# Altium schematic sheet TE0720_JB1.SchDoc(TE0720_JB1)
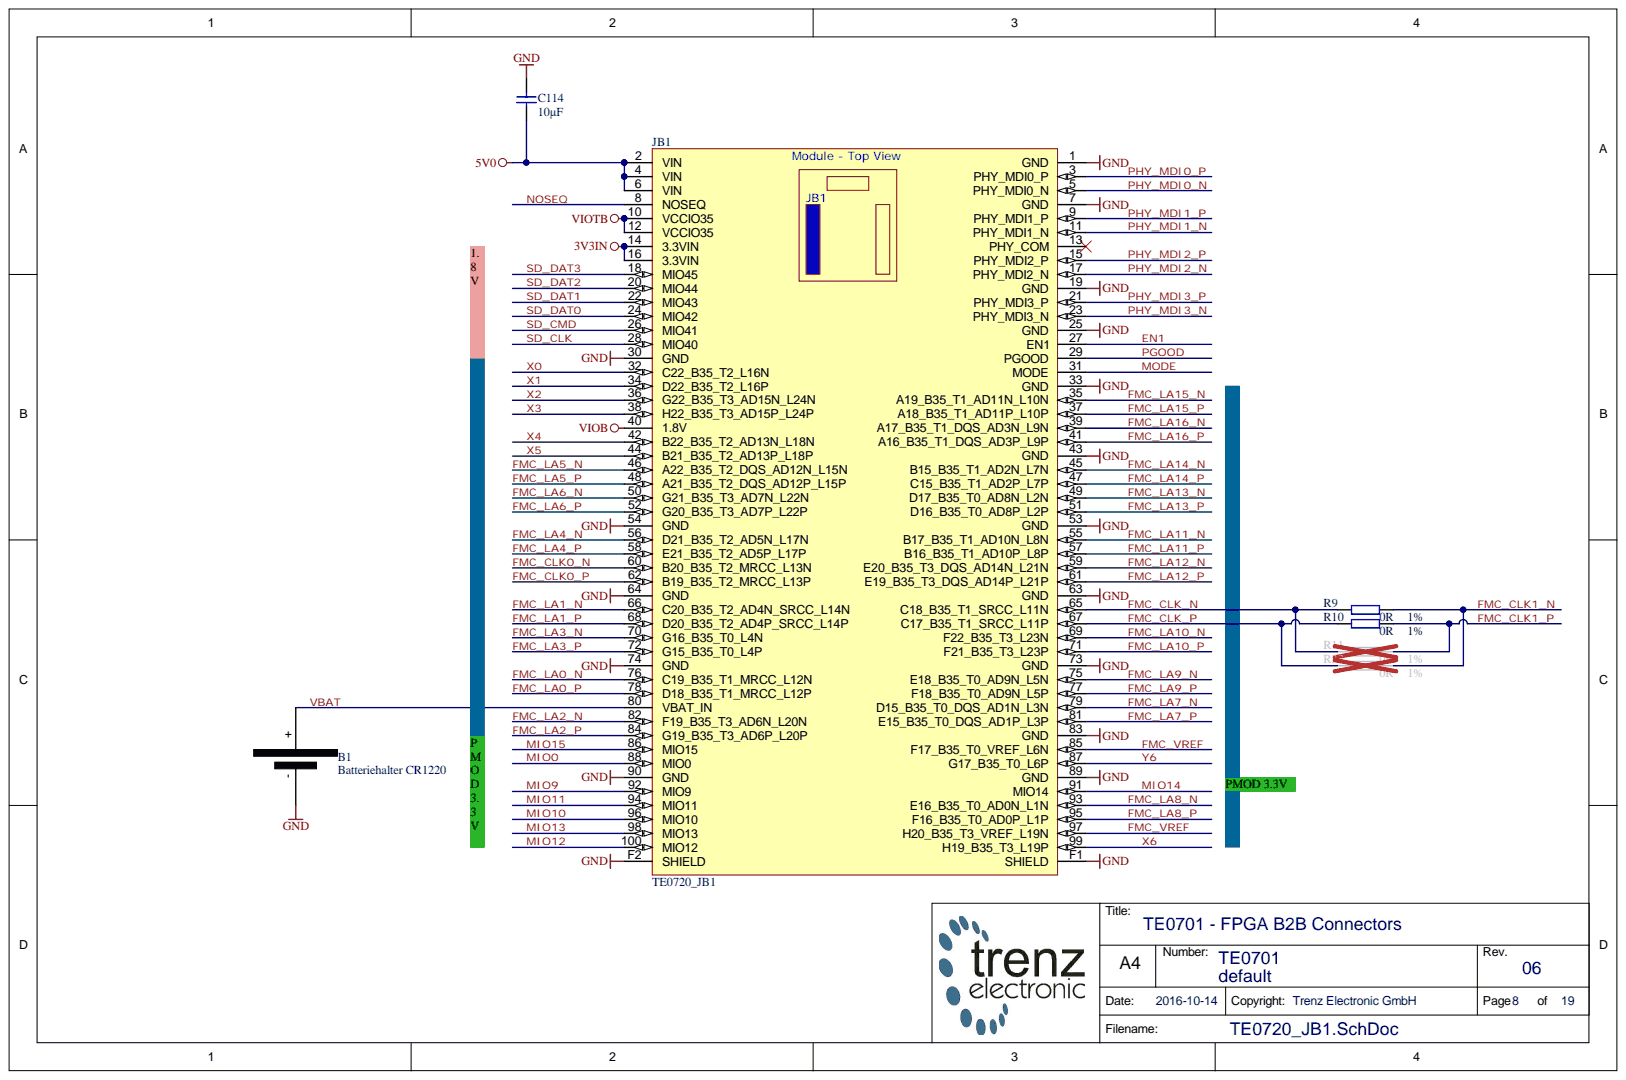
[diagram p0: TE0720_JB1.SchDoc]

## Parts
B1  Batteriehalter CR1220  [CR1220]
C114  10µF  [CAPC2012X135N]
JB1  TE0720_JB1  [LSHM-150-XXX-X-DV-A-X]
R9  0R  Tolerance: 1%  [RESC1005X40N]
R10  0R  Tolerance: 1%  [RESC1005X40N]
R11  0R  Tolerance: 1%  [RESC1005X40N]
R33  0R  Tolerance: 1%  [RESC1005X40N]

## Nets
3V3IN: JB1.14(3.3VIN) JB1.16(3.3VIN)
5V0: C114.2 JB1.2(VIN) JB1.4(VIN) JB1.6(VIN)
GND: B1.- C114.1 JB1.1(GND) JB1.7(GND) JB1.19(GND) JB1.25(GND) JB1.30(GND) JB1.33(GND) JB1.43(GND) JB1.53(GND) JB1.54(GND) JB1.63(GND) JB1.64(GND) JB1.73(GND) JB1.74(GND) JB1.83(GND) JB1.89(GND) JB1.90(GND) JB1.F1(SHIELD) JB1.F2(SHIELD)
VIOB: JB1.40(1.8V)
VIOTB: JB1.10(VCCIO35) JB1.12(VCCIO35)
EN1: JB1.27(EN1)
FMC_CLK0_N: JB1.60(B20_B35_T2_MRCC_L13N)
FMC_CLK0_P: JB1.62(B19_B35_T2_MRCC_L13P)
FMC_CLK1_N: R9.2 R33.2
FMC_CLK1_P: R10.2 R11.2
FMC_CLK_N: JB1.65(C18_B35_T1_SRCC_L11N) R9.1 R11.1
FMC_CLK_P: JB1.67(C17_B35_T1_SRCC_L11P) R10.1 R33.1
FMC_LA0_N: JB1.76(C19_B35_T1_MRCC_L12N)
FMC_LA0_P: JB1.78(D18_B35_T1_MRCC_L12P)
FMC_LA1_N: JB1.66(C20_B35_T2_AD4N_SRCC_L14N)
FMC_LA1_P: JB1.68(D20_B35_T2_AD4P_SRCC_L14P)
FMC_LA2_N: JB1.82(F19_B35_T3_AD6N_L20N)
FMC_LA2_P: JB1.84(G19_B35_T3_AD6P_L20P)
FMC_LA3_N: JB1.70(G16_B35_T0_L4N)
FMC_LA3_P: JB1.72(G15_B35_T0_L4P)
FMC_LA4_N: JB1.56(D21_B35_T2_AD5N_L17N)
FMC_LA4_P: JB1.58(E21_B35_T2_AD5P_L17P)
FMC_LA5_N: JB1.46(A22_B35_T2_DQS_AD12N_L15N)
FMC_LA5_P: JB1.48(A21_B35_T2_DQS_AD12P_L15P)
FMC_LA6_N: JB1.50(G21_B35_T3_AD7N_L22N)
FMC_LA6_P: JB1.52(G20_B35_T3_AD7P_L22P)
FMC_LA7_N: JB1.79(D15_B35_T0_DQS_AD1N_L3N)
FMC_LA7_P: JB1.81(E15_B35_T0_DQS_AD1P_L3P)
FMC_LA8_N: JB1.93(E16_B35_T0_AD0N_L1N)
FMC_LA8_P: JB1.95(F16_B35_T0_AD0P_L1P)
FMC_LA9_N: JB1.75(E18_B35_T0_AD9N_L5N)
FMC_LA9_P: JB1.77(F18_B35_T0_AD9N_L5P)
FMC_LA10_N: JB1.69(F22_B35_T3_L23N)
FMC_LA10_P: JB1.71(F21_B35_T3_L23P)
FMC_LA11_N: JB1.55(B17_B35_T1_AD10N_L8N)
FMC_LA11_P: JB1.57(B16_B35_T1_AD10P_L8P)
FMC_LA12_N: JB1.59(E20_B35_T3_DQS_AD14N_L21N)
FMC_LA12_P: JB1.61(E19_B35_T3_DQS_AD14P_L21P)
FMC_LA13_N: JB1.49(D17_B35_T0_AD8N_L2N)
FMC_LA13_P: JB1.51(D16_B35_T0_AD8P_L2P)
FMC_LA14_N: JB1.45(B15_B35_T1_AD2N_L7N)
FMC_LA14_P: JB1.47(C15_B35_T1_AD2P_L7P)
FMC_LA15_N: JB1.35(A19_B35_T1_AD11N_L10N)
FMC_LA15_P: JB1.37(A18_B35_T1_AD11P_L10P)
FMC_LA16_N: JB1.39(A17_B35_T1_DQS_AD3N_L9N)
FMC_LA16_P: JB1.41(A16_B35_T1_DQS_AD3P_L9P)
FMC_VREF: JB1.85(F17_B35_T0_VREF_L6N) JB1.97(H20_B35_T3_VREF_L19N)
MIO0: JB1.88(MIO0)
MIO9: JB1.92(MIO9)
MIO10: JB1.96(MIO10)
MIO11: JB1.94(MIO11)
MIO12: JB1.100(MIO12)
MIO13: JB1.98(MIO13)
MIO14: JB1.91(MIO14)
MIO15: JB1.86(MIO15)
MODE: JB1.31(MODE)
NOSEQ: JB1.8(NOSEQ)
PGOOD: JB1.29(PGOOD)
PHY_MDI0_N: JB1.5(PHY_MDI0_N)
PHY_MDI0_P: JB1.3(PHY_MDI0_P)
PHY_MDI1_N: JB1.11(PHY_MDI1_N)
PHY_MDI1_P: JB1.9(PHY_MDI1_P)
PHY_MDI2_N: JB1.17(PHY_MDI2_N)
PHY_MDI2_P: JB1.15(PHY_MDI2_P)
PHY_MDI3_N: JB1.23(PHY_MDI3_N)
PHY_MDI3_P: JB1.21(PHY_MDI3_P)
SD_CLK: JB1.28(MIO40)
SD_CMD: JB1.26(MIO41)
SD_DAT0: JB1.24(MIO42)
SD_DAT1: JB1.22(MIO43)
SD_DAT2: JB1.20(MIO44)
SD_DAT3: JB1.18(MIO45)
VBAT: B1.+ JB1.80(VBAT_IN)
X0: JB1.32(C22_B35_T2_L16N)
X1: JB1.34(D22_B35_T2_L16P)
X2: JB1.36(G22_B35_T3_AD15N_L24N)
X3: JB1.38(H22_B35_T3_AD15P_L24P)
X4: JB1.42(B22_B35_T2_AD13N_L18N)
X5: JB1.44(B21_B35_T2_AD13P_L18P)
X6: JB1.99(H19_B35_T3_L19P)
Y6: JB1.87(G17_B35_T0_L6P)

Not connected: JB1 13(PHY_COM)

## Library data of the parts
B1 = 25140: Database Table Name: BAT; H-ART: BC501SM; Mixed: THT; Trenz-Stock: Yes; AN: 25140; Case: CD1220; Component Kind: Standard; Description: Batteriehalter CR1220; Library Name: TE.DbLib; HelpURL: B:\AD\adlib\datasheet\BC501SM
C114 = 24380: KON 10µF 16V X7R SMD 10%; Database Table Name: C_2012_0805; Mixed: SMT; Preferred: Yes; Value: 10u; AN: 24380; Case: 2012 (0805); Component Kind: Standard; Library Name: TE.DbLib; Technology: X7R; Tolerance: 10%; Voltage: 16V
JB1 = 25285: TE0720-JB1; Database Table Name: BLOCKS; Mixed: SMT; Trenz-Article-Number: 23838; Trenz-Stock: Yes; AN: 25285; Component Kind: Standard; Library Name: TE.DbLib
R9-R11 R33 = 22738: WID SMD 0R; Database Table Name: R_1005_0402; Mixed: SMT; Preferred: Yes; Value: 0; AN: 22738; Case: 1005 (0402); Component Kind: Standard; Library Name: TE.DbLib; Technology: TK100
